# Altium schematic sheet ESP8266控制器.SchDoc(ESP8266控制器)
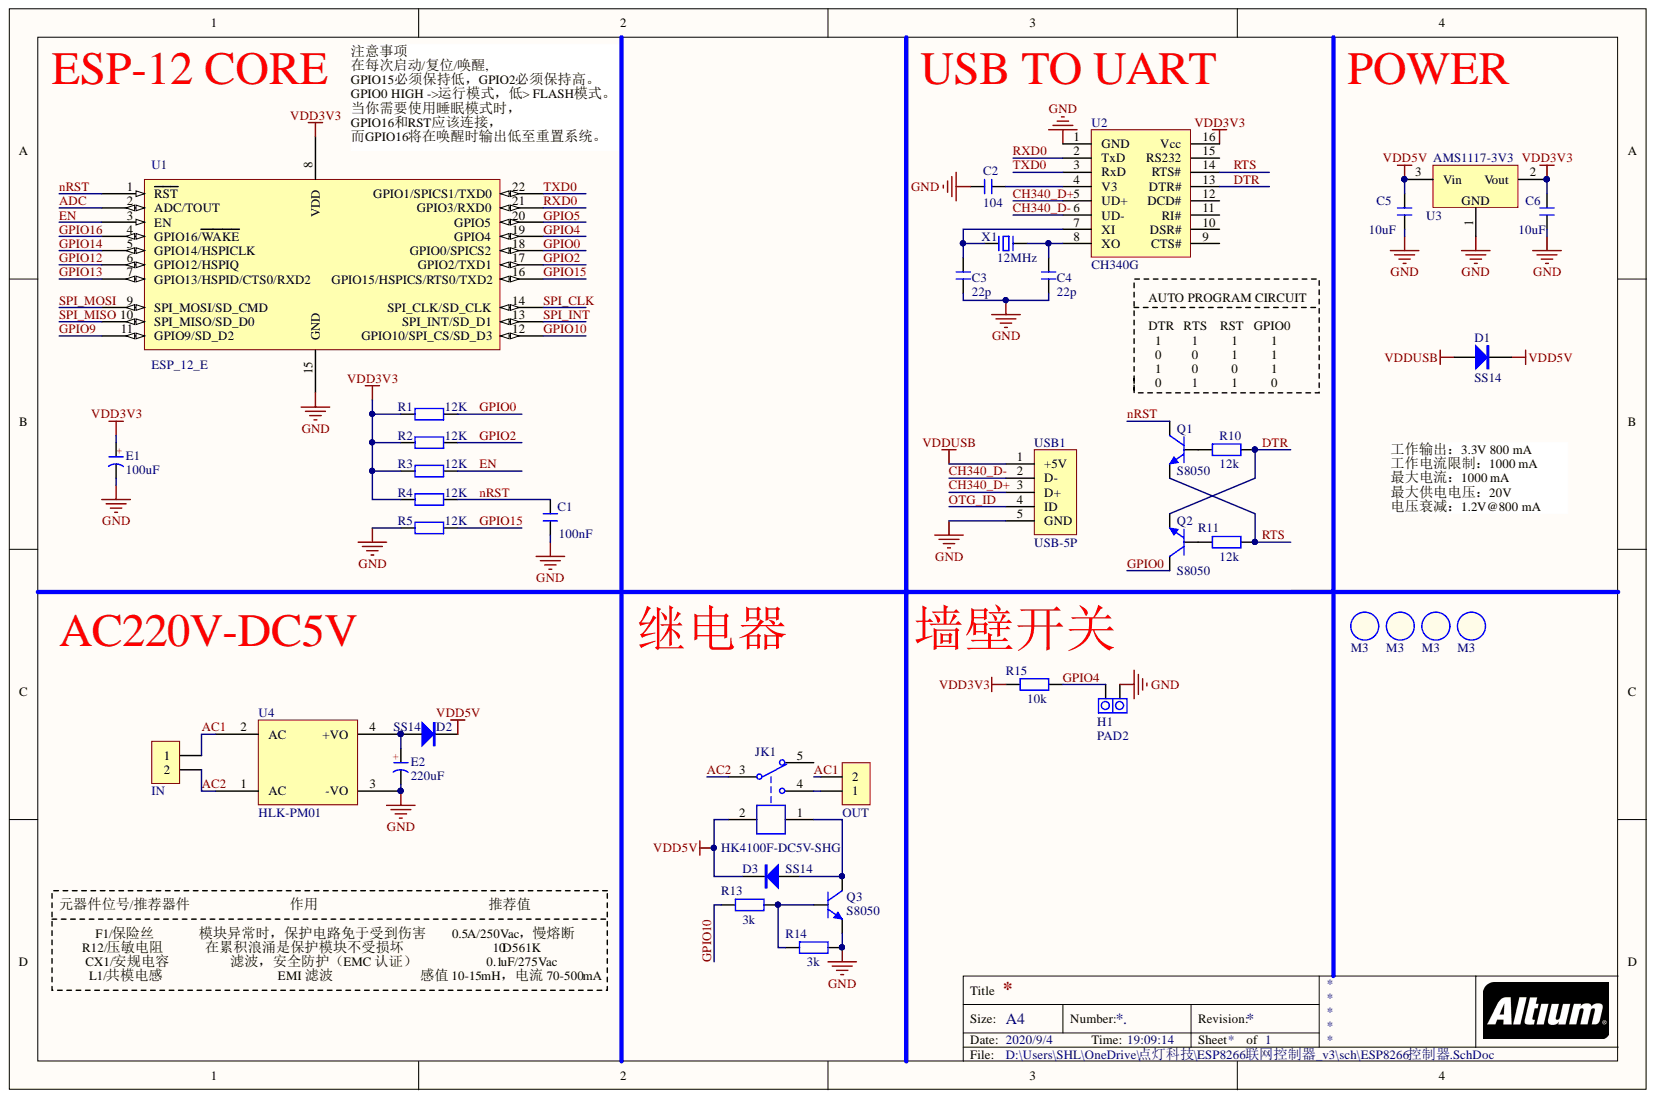
[diagram p0: ESP8266控制器.SchDoc]

## Parts
1  M3  [M3 125X200]
2  M3  [M3 125X200]
3  M3  [M3 125X200]
4  M3  [M3 125X200]
C1  100nF  [C 0603_M]
C2  104  [C 0603_M]
C3  22p  [C 0603_M]
C4  22p  [C 0603_M]
C5  10uF  [C 0603_M]
C6  10uF  [C 0603_M]
D1  SS14  [SMA]
D2  SS14  [SMA]
D3  SS14  [SMA]
E1  100uF  [CD D(7343)]
E2  220uF  [CD D(7343)]
H1  PAD2  [PAD2-2.54]
IN  HDR-1X2  [KF301-5.0-2P]  (value not drawn)
JK1  HK4100F-DC5V-SHG  [HK4100F]
OUT  HDR-1X2  [KF301-5.0-2P]  (value not drawn)
Q1  S8050  [SOT23-3N]
Q2  S8050  [SOT23-3N]
Q3  S8050  [SOT23-3N]
R1  12K  [R 0603_M]
R2  12K  [R 0603_M]
R3  12K  [R 0603_M]
R4  12K  [R 0603_M]
R5  12K  [R 0603_M]
R10  12k  [R 0603_M]
R11  12k  [R 0603_M]
R13  3k  [R 0603_M]
R14  3k  [R 0603_M]
R15  10k  [R 0603_M]
U1  ESP_12_E  [ESP_12_E_L]
U2  CH340G  [SOP16_L]
U3  AMS1117-3V3  [SOT223_L]
U4  HLK-PM01  [HLK-PM01]
USB1  USB-5P  [USB-MICRO_D]
X1  12MHz  [OSC HC-49S]

## Nets
GND: C1.1 C2.2 C3.2 C4.2 C5.2 C6.2 E1.2 E2.2 H1.1 Q3.2 R5.1 R14.1 U1.15(GND) U2.1(GND) U3.1 U4.3(-VO) USB1.5(GND)
VDD3V3: C6.1 E1.1 R1.1 R2.1 R3.1 R4.1 R15.2 U1.8(VDD) U2.16(Vcc) U3.2
VDD5V: C5.1 D1.2 D2.2 D3.2 JK1.2 U3.3
VDDUSB: D1.1 USB1.1(+5V)
AC1: IN.1 OUT.2 U4.2(AC)
AC2: IN.2 JK1.3 U4.1(AC)
ADC: U1.2(ADC/TOUT)
CH340_D+: U2.5(UD+) USB1.3(D+)
CH340_D-: U2.6(UD-) USB1.2(D-)
DTR: Q2.2 R10.1 U2.13(DTR#)
EN: R3.2 U1.3(EN)
GPIO0: Q2.3 R1.2 U1.18(GPIO0/SPICS2)
GPIO2: R2.2 U1.17(GPIO2/TXD1)
GPIO4: H1.2 R15.1 U1.19(GPIO4)
GPIO5: U1.20(GPIO5)
GPIO9: U1.11(GPIO9/SD_D2)
GPIO10: R13.2 U1.12(GPIO10/SPI_CS/SD_D3)
GPIO12: U1.6(GPIO12/HSPIQ)
GPIO13: U1.7(GPIO13/HSPID/CTS0/RXD2)
GPIO14: U1.5(GPIO14/HSPICLK)
GPIO15: R5.2 U1.16(GPIO15/HSPICS/RTS0/TXD2)
GPIO16: U1.4(GPIO16/WAKE)
nRST: C1.2 Q1.3 R4.2 U1.1(RST)
OTG_ID: USB1.4(ID)
RTS: Q1.2 R11.1 U2.14(RTS#)
RXD0: U1.21(GPIO3/RXD0) U2.2(TxD)
SPI_CLK: U1.14(SPI_CLK/SD_CLK)
SPI_INT: U1.13(SPI_INT/SD_D1)
SPI_MISO: U1.10(SPI_MISO/SD_D0)
SPI_MOSI: U1.9(SPI_MOSI/SD_CMD)
TXD0: U1.22(GPIO1/SPICS1/TXD0) U2.3(RxD)
NetC2_1: C2.1 U2.4(V3)
NetC3_1: C3.1 U2.7(XI) X1.1
NetC4_1: C4.1 U2.8(XO) X1.2
NetD2_1: D2.1 E2.1 U4.4(+VO)
NetD3_1: D3.1 JK1.1 Q3.3
NetJK1_4: JK1.4 OUT.1
NetQ1_1: Q1.1 R10.2
NetQ2_1: Q2.1 R11.2
NetQ3_1: Q3.1 R13.1 R14.2

Not connected: JK1 5; U2 9(CTS#) 10(DSR#) 11(RI#) 12(DCD#) 15(RS232)

## Library data of the parts
1-4 = M3: 铜柱孔; 版权归属: 源创客; 学习资源: www.ooocke.com; Component Kind: Standard; BOM名称: 铜柱孔; Library Name: 海龍集成库.IntLib
C1-C6 = C: 无极性贴片电容; 版权归属: 源创客; 学习资源: www.ooocke.com; Component Kind: Standard; BOM名称: 无极性贴片电容; Library Name: 海龍集成库.IntLib
D1-D3 = SS14: 肖特基二极管; 版权归属: 源创客; 属性: 40V/1A; 学习资源: www.ooocke.com; Component Kind: Standard; BOM名称: 肖特基二极管; Library Name: 海龍集成库.IntLib
E1 E2 = C-CD: 贴片钽电容; 版权归属: 源创客; 学习资源: www.ooocke.com; Component Kind: Standard; BOM名称: 贴片钽电容; Library Name: 海龍集成库.IntLib
H1 = PAD2: 引线焊盘; 版权归属: 源创客; 学习资源: www.ooocke.com; Component Kind: Standard; BOM名称: 引线焊盘; Library Name: 海龍集成库.IntLib
IN OUT = Header 2 5.0: 2P接插件; 版权归属: 源创客; 学习资源: www.ooocke.com; Component Kind: Standard; BOM名称: 2P接插件; Library Name: 海龍集成库.IntLib
JK1 = HK4100F: 一组转换; 版权归属: 源创客; BOM名称: 一组继电器; 学习资源: www.ooocke.com; Component Kind: Standard; Library Name: 海龍集成库.IntLib
Q1-Q3 = 8050-SMD: 高频放大-NPN型; 版权归属: 源创客; 属性: 40V 1.5A 1W 100MHZ; 学习资源: www.ooocke.com; Component Kind: Standard; BOM名称: 高频放大-NPN型; Library Name: 海龍集成库.IntLib
R1-R5 R10 R11 R13-R15 = R: 贴片电阻; 版权归属: 源创客; 学习资源: www.ooocke.com; Component Kind: Standard; BOM名称: 贴片电阻; Library Name: 海龍集成库.IntLib
U1 = ESP_12_E: ESP-12 Wi-Fi Module by AI-Thinker; IC: ESP8266-EX; Vendor: Ai-thinker; Library Name: NODEMCU_DEVKIT_V1.0.IntLib; Component Kind: Standard
U2 = CH340G: 串口转USB; 版权归属: 源创客; 学习资源: www.ooocke.com; Component Kind: Standard; BOM名称: 串口转USB; Library Name: 海龍集成库.IntLib
U3 = AMS1117: 三端稳压芯片; 版权归属: 源创客; BOM名称: 稳压芯片; 学习资源: www.ooocke.com; Component Kind: Standard; Library Name: 海龍集成库.IntLib
U4 = Component_1: 220V转5V模块; Component Kind: Standard; Library Name: ESP8266控制器.SchLib
USB1 = USB-5P: 微型USB母座; 版权归属: 源创客; 学习资源: www.ooocke.com; Component Kind: Standard; BOM名称: 微型USB母座; Library Name: 海龍集成库.IntLib
X1 = XTAL-2P: 2脚无源脚晶振; 版权归属: 源创客; 学习资源: www.ooocke.com; Component Kind: Standard; BOM名称: 2脚无源脚晶振; Library Name: 海龍集成库.IntLib
